# Altium schematic sheet cwh_micro.SchDoc
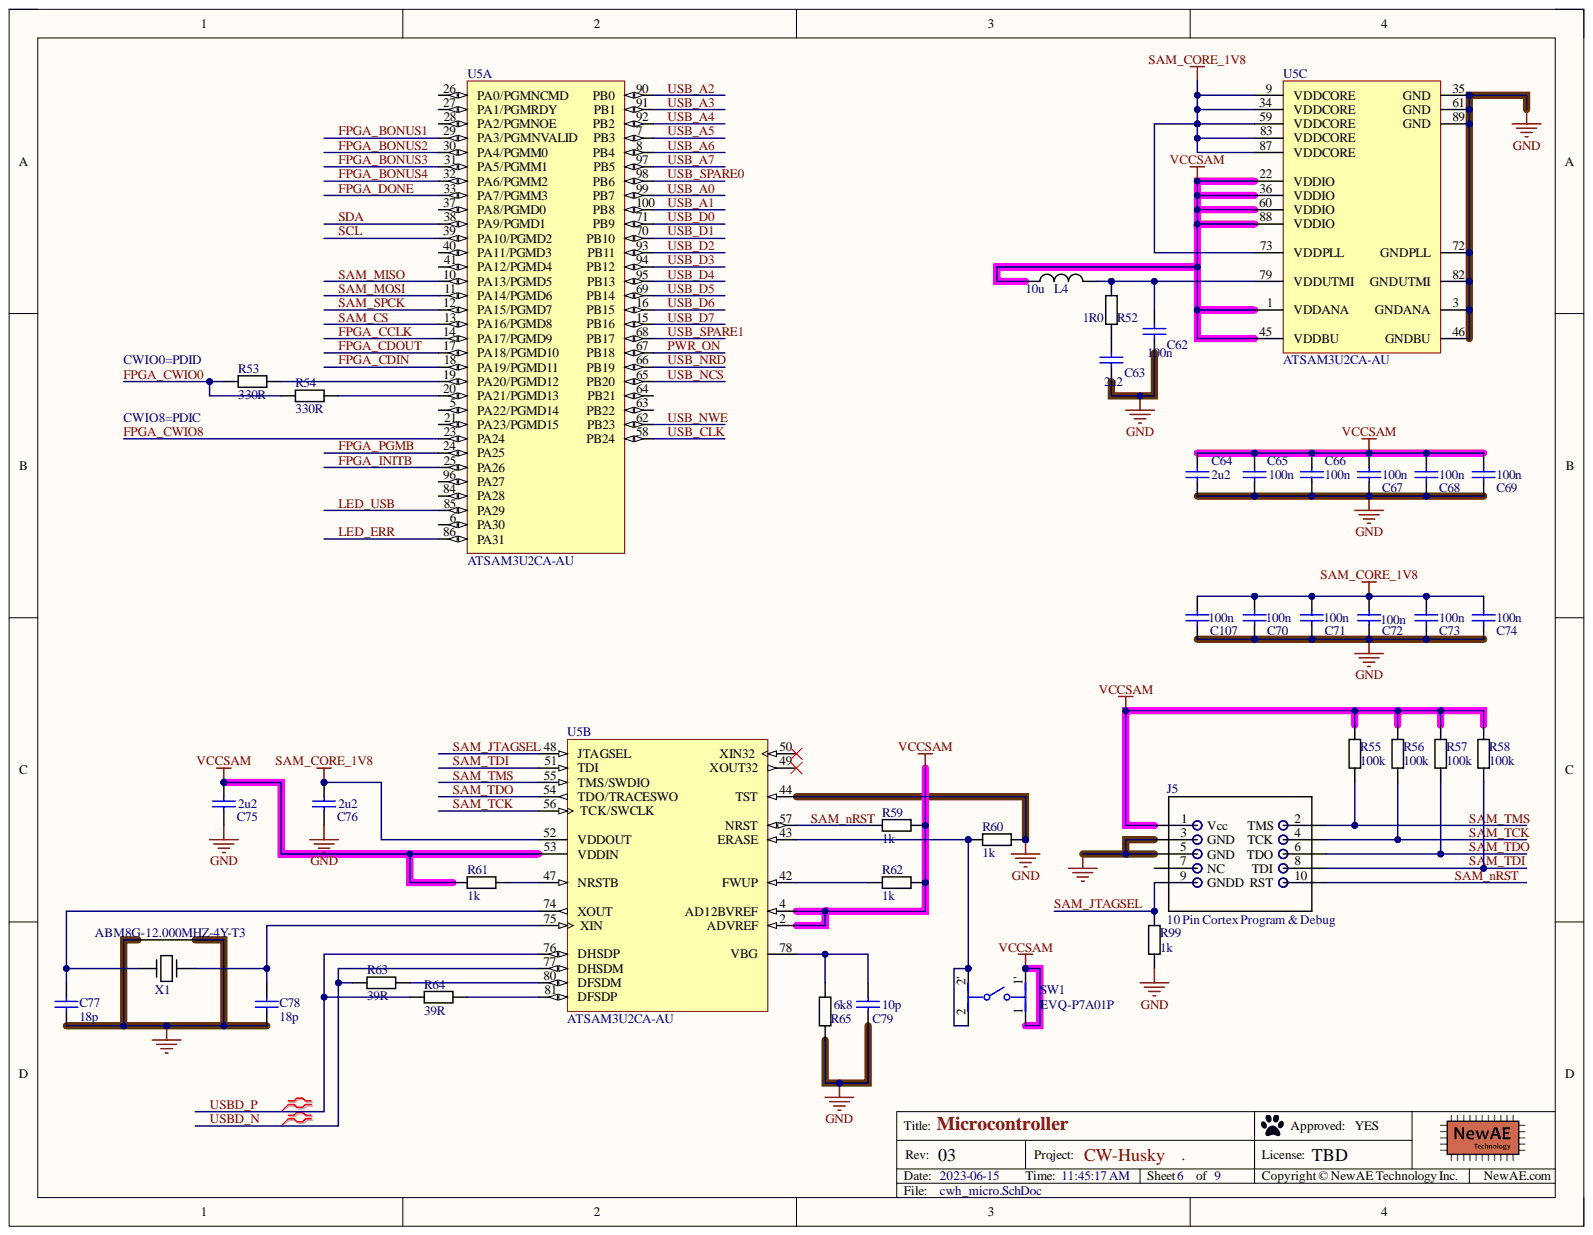
[diagram p0: cwh_micro.SchDoc]

## Parts
C62  100n  [0402]
C63  2u2  [0603]
C64  2u2  [0603]
C65  100n  [0402]
C66  100n  [0402]
C67  100n  [0402]
C68  100n  [0402]
C69  100n  [0402]
C70  100n  [0402]
C71  100n  [0402]
C72  100n  [0402]
C73  100n  [0402]
C74  100n  [0402]
C75  2u2  [0603]
C76  2u2  [0603]
C77  18p  [0402]
C78  18p  [0402]
C79  10p  [0603]
C107  100n  [0402]
J5  10 Pin Cortex Program & Debug  [0.5mm_SMD_Header_5x2]
L4  10u  [0603]
R52  1R0  [0603]
R53  330R  [0402]
R54  330R  [0402]
R55  100k  [0603]
R56  100k  [0603]
R57  100k  [0603]
R58  100k  [0603]
R59  1k  [0603]
R60  1k  [0603]
R61  1k  [0603]
R62  1k  [0603]
R63  39R  [0603]
R64  39R  [0603]
R65  6k8  [0603]
R99  1k  [0603]
SW1  EVQ-P7A01P  [FP-EVQ-P7A01P-MFG]
U5  ATSAM3U2CA-AU  [LQFP100_L]  (parts A,B,C)
X1  ABM8G-12.000MHZ-4Y-T3  [ABM8G]

## Nets
GND: C62.2 C63.2 C64.2 C65.2 C66.2 C67.2 C68.2 C69.2 C70.2 C71.2 C72.2 C73.2 C74.2 C75.2 C76.2 C77.2 C78.2 C79.2 C107.2 J5.3(GND) J5.5(GND) R60.2 R65.2 R99.1 U5.3(GNDANA) U5.35(GND) U5.44(TST) U5.46(GNDBU) U5.61(GND) U5.72(GNDPLL) U5.82(GNDUTMI) U5.89(GND) X1.2 X1.4
SAM_CORE_1V8: C70.1 C71.1 C72.1 C73.1 C74.1 C76.1 C107.1 U5.9(VDDCORE) U5.34(VDDCORE) U5.52(VDDOUT) U5.59(VDDCORE) U5.73(VDDPLL) U5.83(VDDCORE) U5.87(VDDCORE)
VCCSAM: C64.1 C65.1 C66.1 C67.1 C68.1 C69.1 C75.1 J5.1(Vcc) L4.1 R55.1 R56.1 R57.1 R58.1 R59.2 R61.1 R62.2 SW1.1 SW1.1' U5.1(VDDANA) U5.2(ADVREF) U5.4(AD12BVREF) U5.22(VDDIO) U5.36(VDDIO) U5.45(VDDBU) U5.53(VDDIN) U5.60(VDDIO) U5.88(VDDIO)
FPGA_BONUS1: U5.29(PA3/PGMNVALID)
FPGA_BONUS2: U5.30(PA4/PGMM0)
FPGA_BONUS3: U5.31(PA5/PGMM1)
FPGA_BONUS4: U5.32(PA6/PGMM2)
FPGA_CCLK: U5.14(PA17/PGMD9)
FPGA_CDIN: U5.18(PA19/PGMD11)
FPGA_CDOUT: U5.17(PA18/PGMD10)
FPGA_CWIO0: R53.1 R54.1
FPGA_CWIO8: U5.23(PA24)
FPGA_DONE: U5.33(PA7/PGMM3)
FPGA_INITB: U5.25(PA26)
FPGA_PGMB: U5.24(PA25)
LED_ERR: U5.86(PA31)
LED_USB: U5.85(PA29)
PWR_ON: U5.67(PB18)
SAM_CS: U5.13(PA16/PGMD8)
SAM_JTAGSEL: J5.9(GNDD) R99.2 U5.48(JTAGSEL)
SAM_MISO: U5.10(PA13/PGMD5)
SAM_MOSI: U5.11(PA14/PGMD6)
SAM_nRST: J5.10(RST) R59.1 U5.57(NRST)
SAM_SPCK: U5.12(PA15/PGMD7)
SAM_TCK: J5.4(TCK) R56.2 U5.56(TCK/SWCLK)
SAM_TDI: J5.8(TDI) R58.2 U5.51(TDI)
SAM_TDO: J5.6(TDO) R57.2 U5.54(TDO/TRACESWO)
SAM_TMS: J5.2(TMS) R55.2 U5.55(TMS/SWDIO)
SCL: U5.39(PA10/PGMD2)
SDA: U5.38(PA9/PGMD1)
USB_A0: U5.99(PB7)
USB_A1: U5.100(PB8)
USB_A2: U5.90(PB0)
USB_A3: U5.91(PB1)
USB_A4: U5.92(PB2)
USB_A5: U5.7(PB3)
USB_A6: U5.8(PB4)
USB_A7: U5.97(PB5)
USB_CLK: U5.58(PB24)
USB_D0: U5.71(PB9)
USB_D1: U5.70(PB10)
USB_D2: U5.93(PB11)
USB_D3: U5.94(PB12)
USB_D4: U5.95(PB13)
USB_D5: U5.69(PB14)
USB_D6: U5.16(PB15)
USB_D7: U5.15(PB16)
USB_NCS: U5.65(PB20)
USB_NRD: U5.66(PB19)
USB_NWE: U5.62(PB23)
USB_SPARE0: U5.98(PB6)
USB_SPARE1: U5.68(PB17)
USBD_N: R63.1 U5.77(DHSDM)
USBD_P: R64.1 U5.76(DHSDP)
NetC62_1: C62.1 L4.2 R52.1 U5.79(VDDUTMI)
NetC63_1: C63.1 R52.2
NetC77_1: C77.1 U5.74(XOUT) X1.1
NetC78_1: C78.1 U5.75(XIN) X1.3
NetC79_1: C79.1 R65.1 U5.78(VBG)
NetR53_2: R53.2 U5.19(PA20/PGMD12)
NetR54_2: R54.2 U5.20(PA21/PGMD13)
NetR60_1: R60.1 SW1.2 SW1.2' U5.43(ERASE)
NetR61_2: R61.2 U5.47(NRSTB)
NetR62_1: R62.1 U5.42(FWUP)
NetR63_2: R63.2 U5.80(DFSDM)
NetR64_2: R64.2 U5.81(DFSDP)

Not connected: J5 7(NC); U5 5(PA22/PGMD14) 6(PA30) 21(PA23/PGMD15) 26(PA0/PGMNCMD) 27(PA1/PGMRDY) 28(PA2/PGMNOE) 37(PA8/PGMD0) 40(PA11/PGMD3) 41(PA12/PGMD4) 49(XOUT32) 50(XIN32) 63(PB22) 64(PB21) 84(PA28) 96(PA27)

## Library data of the parts
C62 C65-C74 C107 = CCHIP-100n-0402: 100n, 0402; Manufacture Part Number 1: CGA2B3X7R1V104K050BB; Component Group: Capacitor; Database Table Name: main; Case-EIA: 0402; Component Kind: Standard; Component Type: Standard; Library Name: NewAE_AltiumLib.DBLib; Mounting Technology: SMT; Part Number: CCHIP-100n-0402; Pin Count: 2; Supplier 1: Digi-Key; Supplier Part Number 1: 445-6901-1-ND; Value: 100n
C63 C64 C75 C76 = CCHIP-2u2-0603: 2u2, 0603; Manufacture 1: Samsung; Manufacture Part Number 1: CL10B225KP8NNNC; Case-Metric: 1608; Component Group: Capacitor; Database Table Name: main; Rated Voltage: 10VDC; Case-EIA: 0603; Component Kind: Standard; Component Type: Standard; Library Name: NewAE_AltiumLib.DBLib; Mounting Technology: SMT; Part Number: CCHIP-2u2-0603; Pin Count: 2; Supplier 1: Digi-Key; Supplier Part Number 1: 1276-1134-2-ND; Value: 2u2
C77 C78 = CCHIP-18p-0402: 18p, 0402; Manufacture Part Number 1: GRM1555C1H180JA01D; Component Group: Capacitor; Database Table Name: main; Case-EIA: 0402; Component Kind: Standard; Component Type: Standard; Library Name: NewAE_AltiumLib.DBLib; Mounting Technology: SMT; Part Number: CCHIP-18p-0402; Pin Count: 2; Supplier 1: Digi-Key; Supplier Part Number 1: 490-5858-1-ND; Value: 18p
C79 = CCHIP-10p-0603: 10p, 0603; Case-Metric: 1608; Component Group: Capacitor; Database Table Name: main; Case-EIA: 0603; Component Kind: Standard; Component Type: Standard; Library Name: NewAE_AltiumLib.DBLib; Mounting Technology: SMT; Part Number: CCHIP-10p-0603; Pin Count: 2; Supplier 1: Digi-Key; Supplier Part Number 1: 399-1049-1-ND; Value: 10p
J5 = Cortex_Debug_Header_10Pin: 10 Pin Cortex Program & Debug 0.5mm pitch; Manufacture Part Number 1: 20021121-00010C4LF; Component Group: Connector; Database Table Name: main; PP Material Stack: 0; Component Kind: Standard; Component Type: Standard; Library Name: NewAE_AltiumLib.DBLib; Mounting Technology: SMT; Part Number: Cortex_Debug_Header_10Pin; Pin Count: 10; PP Rotation: 0; RoHS: Yes; Supplier 1: Digi-Key; Supplier Part Number 1: 609-3695-1-ND
L4 = LCHIP-10U-0603: FIXED IND 10UH 50MA 900 MOHM SMD; Manufacture 1: Murata Electronics North America; Manufacture Part Number 1: LQM18FN100M00D; Case-Metric: 1608; Component Group: Inductor; Database Table Name: main; PP Material Stack: 0; Tolerance: ±20%; Case-EIA: 0603; Component Kind: Standard; Component Type: Standard; Library Name: NewAE_AltiumLib.DBLib; Mounting Technology: SMT; Part Number: LCHIP-10U-0603; Pin Count: 2; PP Rotation: 0; Supplier 1: Digi-Key; Supplier Part Number 1: 490-4025-1-ND; Value: 10u
R52 = RCHIP-1R0-0603: 1R0 res, 0603, 1%, 1/10W; Manufacture 1: Yageo; Manufacture Part Number 1: RC0603FR-071RL; Case-Metric: 1608; Component Group: Resistor; Database Table Name: main; Rated Power: 0.1W; Rated Voltage: 75VDC; Case-EIA: 0603; Component Kind: Standard; Component Type: Standard; Library Name: NewAE_AltiumLib.DBLib; Mounting Technology: SMT; Part Number: RCHIP-1R0-0603; Pin Count: 2; Supplier 1: Digi-Key; Supplier Part Number 1: 311-1.00HRCT-ND; Temperature Range: -55C to 155C; Tolerance: 1%; Value: 1R0
R53 R54 = RCHIP-330R-0402: 330R res, 0402, 1%, 1/16W; Manufacture 1: Yageo; Manufacture Part Number 1: RC0402FR-07330RL; Case-Metric: 1005; Component Group: Resistor; Database Table Name: main; Rated Power: 0.063W; Rated Voltage: 75VDC; Case-EIA: 0402; Component Kind: Standard; Component Type: Standard; Library Name: NewAE_AltiumLib.DBLib; Mounting Technology: SMT; Part Number: RCHIP-330R-0402; Pin Count: 2; Supplier 1: Digi-Key; Supplier Part Number 1: 311-330LRCT-ND; Temperature Range: -55C to 155C; Tolerance: 1%; Value: 330R
R55-R58 = RCHIP-100K-0603: 100k res, 0603, 1%, 1/10W; Manufacture 1: Yageo; Manufacture Part Number 1: RC0603FR-07100KL; Case-Metric: 1608; Component Group: Resistor; Database Table Name: main; Rated Power: 0.1W; Rated Voltage: 75VDC; Case-EIA: 0603; Component Kind: Standard; Component Type: Standard; Library Name: NewAE_AltiumLib.DBLib; Mounting Technology: SMT; Part Number: RCHIP-100K-0603; Pin Count: 2; Supplier 1: Digi-Key; Supplier Part Number 1: 311-100KHRCT-ND; Temperature Range: -55C to 155C; Tolerance: 1%; Value: 100k
R59-R62 R99 = RCHIP-1K-0603: 1k res, 0603, 1%, 1/10W; Manufacture 1: Yageo; Manufacture Part Number 1: RC0603FR-071KL; Case-Metric: 1608; Component Group: Resistor; Database Table Name: main; Rated Power: 0.1W; Rated Voltage: 75VDC; Case-EIA: 0603; Component Kind: Standard; Component Type: Standard; Library Name: NewAE_AltiumLib.DBLib; Mounting Technology: SMT; Part Number: RCHIP-1K-0603; Pin Count: 2; Supplier 1: Digi-Key; Supplier Part Number 1: 311-1.00KHRCT-ND; Temperature Range: -55C to 155C; Tolerance: 1%; Value: 1k
R63 R64 = RCHIP-39R-0603: 39R res, 0603, 1%, 1/10W; Manufacture 1: Yageo; Manufacture Part Number 1: RC0603FR-0739RL; Case-Metric: 1608; Component Group: Resistor; Database Table Name: main; Rated Power: 0.1W; Rated Voltage: 75VDC; Case-EIA: 0603; Component Kind: Standard; Component Type: Standard; Library Name: NewAE_AltiumLib.DBLib; Mounting Technology: SMT; Part Number: RCHIP-39R-0603; Pin Count: 2; Supplier 1: Digi-Key; Supplier Part Number 1: 311-39.0HRCT-ND; Temperature Range: -55C to 155C; Tolerance: 1%; Value: 39R
R65 = RCHIP-6K8-0603: 6k8 res, 0603, 1%, 1/10W; Manufacture 1: Yageo; Manufacture Part Number 1: RC0603FR-076K8L; Case-Metric: 1608; Component Group: Resistor; Database Table Name: main; Rated Power: 0.1W; Rated Voltage: 75VDC; Case-EIA: 0603; Component Kind: Standard; Component Type: Standard; Library Name: NewAE_AltiumLib.DBLib; Mounting Technology: SMT; Part Number: RCHIP-6K8-0603; Pin Count: 2; Supplier 1: Digi-Key; Supplier Part Number 1: 311-6.80KHRCT-ND; Temperature Range: -55C to 155C; Tolerance: 1%; Value: 6k8
SW1 = CMP-05618-000007-1: SWITCH TACTILE SPST-NO 0.05A 12V; Manufacturer: Panasonic; Contact Current Rating: 50mA; Contact Resistance: 500mΩ; Electromechanical Life: 100000Cycles; Operating Force: 2.2N; Automotive: No; Category: Switch; Component Kind: Standard; ComponentLink2Description: Datasheet; ComponentLink2URL: https://industrial.panasonic.com/cdbs/www-data/pdf/ATK0000/ATK0000C378.pdf; Device Class L1: Electromechanical; Device Class L2: Switches; Device Class L3: Tactile Switches; Digikey Description: SWITCH TACTILE SPST-NO 0.05A 12V; Height: 1.55mm; Lead Free: Yes; Library Name: Altium Content Vault; Manufacturer Part Number: EVQ-P7A01P; Mount: Surface Mount; Mouser Description: SWITCH TACTILE SPST-NO 0.05A 12V; Octopart: https://octopart.com/evq-p7a01p-panasonic-29917075; Package: EVQ-P7A01P; RoHS: TRUE; Temperature: -20°C to +70°C; Temperature Range High: +70°C; Temperature Range Low: -20°C; Throw Configuration: SPST-NO; Voltage Rating Dc: 12V
U5 = CMP-0076-00087-2: AT91 ARM Cortex-M3 32-bit Microcontroller, 128KB Flash, 36KB SRAM, 57 User I/Os, 3USARTs, 100-Pin LQFP, Industrial Grade (-40°C to 85°C), Pb-Free; Code_JEDEC: MS-026-BED; Component Kind: Standard; ComponentLink1Description: Manufacturer URL; ComponentLink1URL: http://www.atmel.com/; ComponentLink2Description: SAM3U Series Datasheet; ComponentLink2URL: http://www.atmel.com/dyn/resources/prod_documents/doc6430.pdf; ComponentLink3Description: SAM3U Series Summary; ComponentLink3URL: http://www.atmel.com/dyn/resources/prod_documents/6430s.pdf; DatasheetVersion: revC, Apr-2010; Library Name: Altium Content Vault; PackageDescription: 100-Pin Thin Quad Flat Pack 0.5 mm Pitch; PackageReference: LQFP100; PackageVersion: Apr-2006; PartId: 1; RevisionNote: DatasheetVersion updated. URLs added.
X1 = ABM8G-12.000MHZ-4Y-T3: CRYSTAL 12.0000MHZ 10PF SMD; Manufacture 1: Abracon LLC; Database Table Name: main; Component Group: Crystal; Component Kind: Standard; Component Type: Standard; Library Name: NewAE_AltiumLib.DBLib; Manufacture Part Number 1: ABM8G-12.000MHZ-4Y-T3; Part Number: ABM8G-12.000MHZ-4Y-T3; Pin Count: 4; Supplier 1: Digi-Key; Supplier Part Number 1: 535-10901-1-ND; Value: 12.000MHz
